# Altium schematic sheet LoRa_SX1262.SchDoc
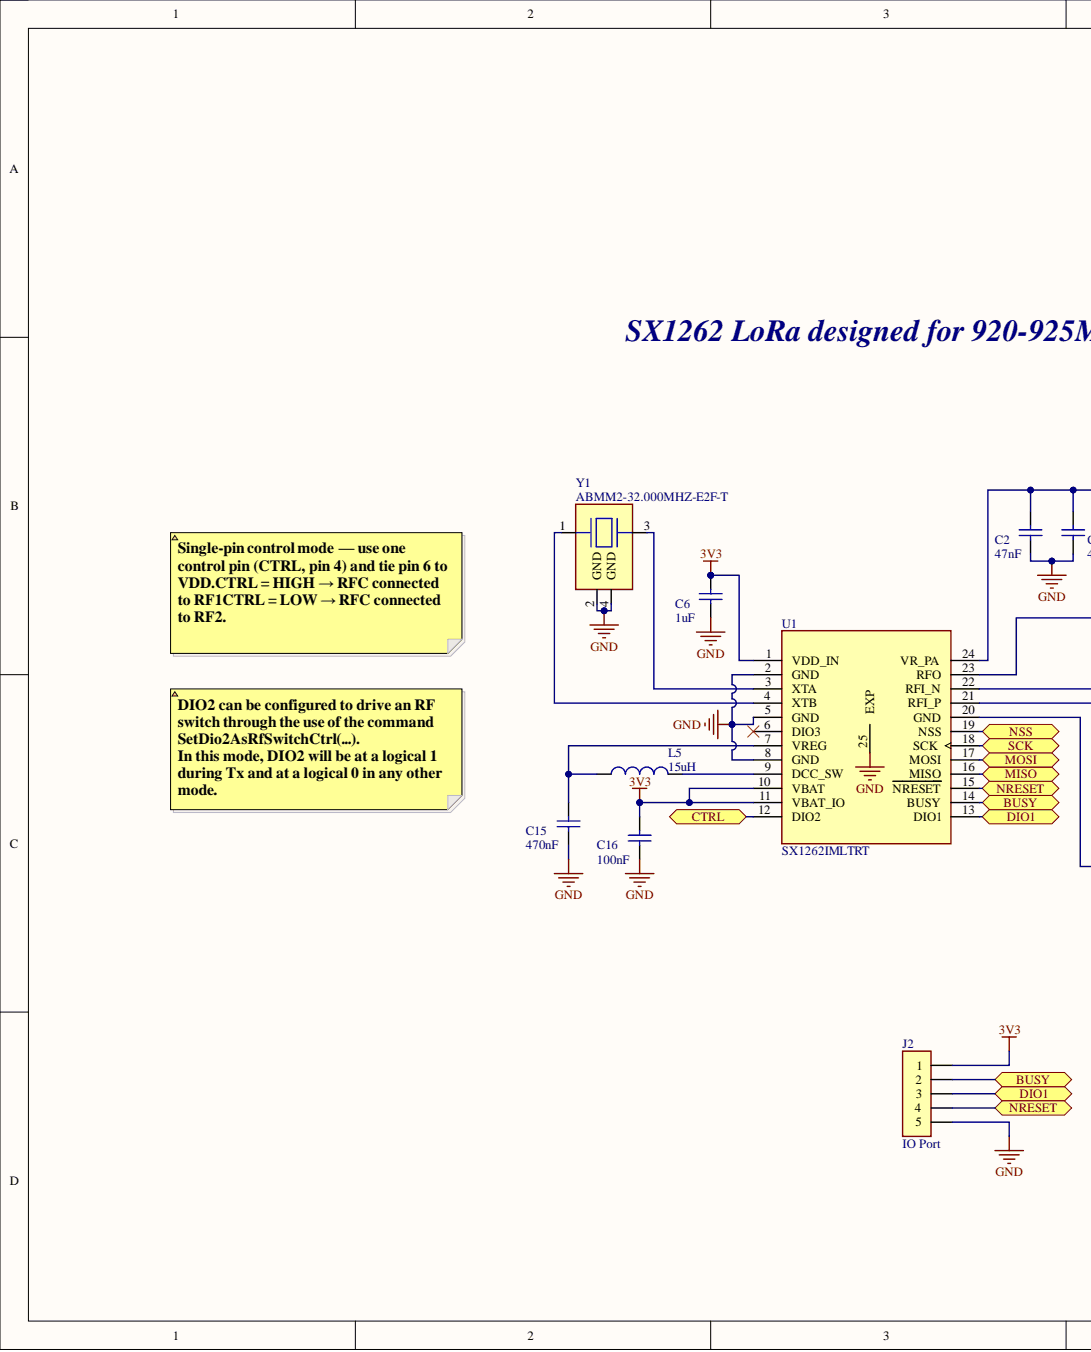
[diagram p0: LoRa_SX1262.SchDoc, left]
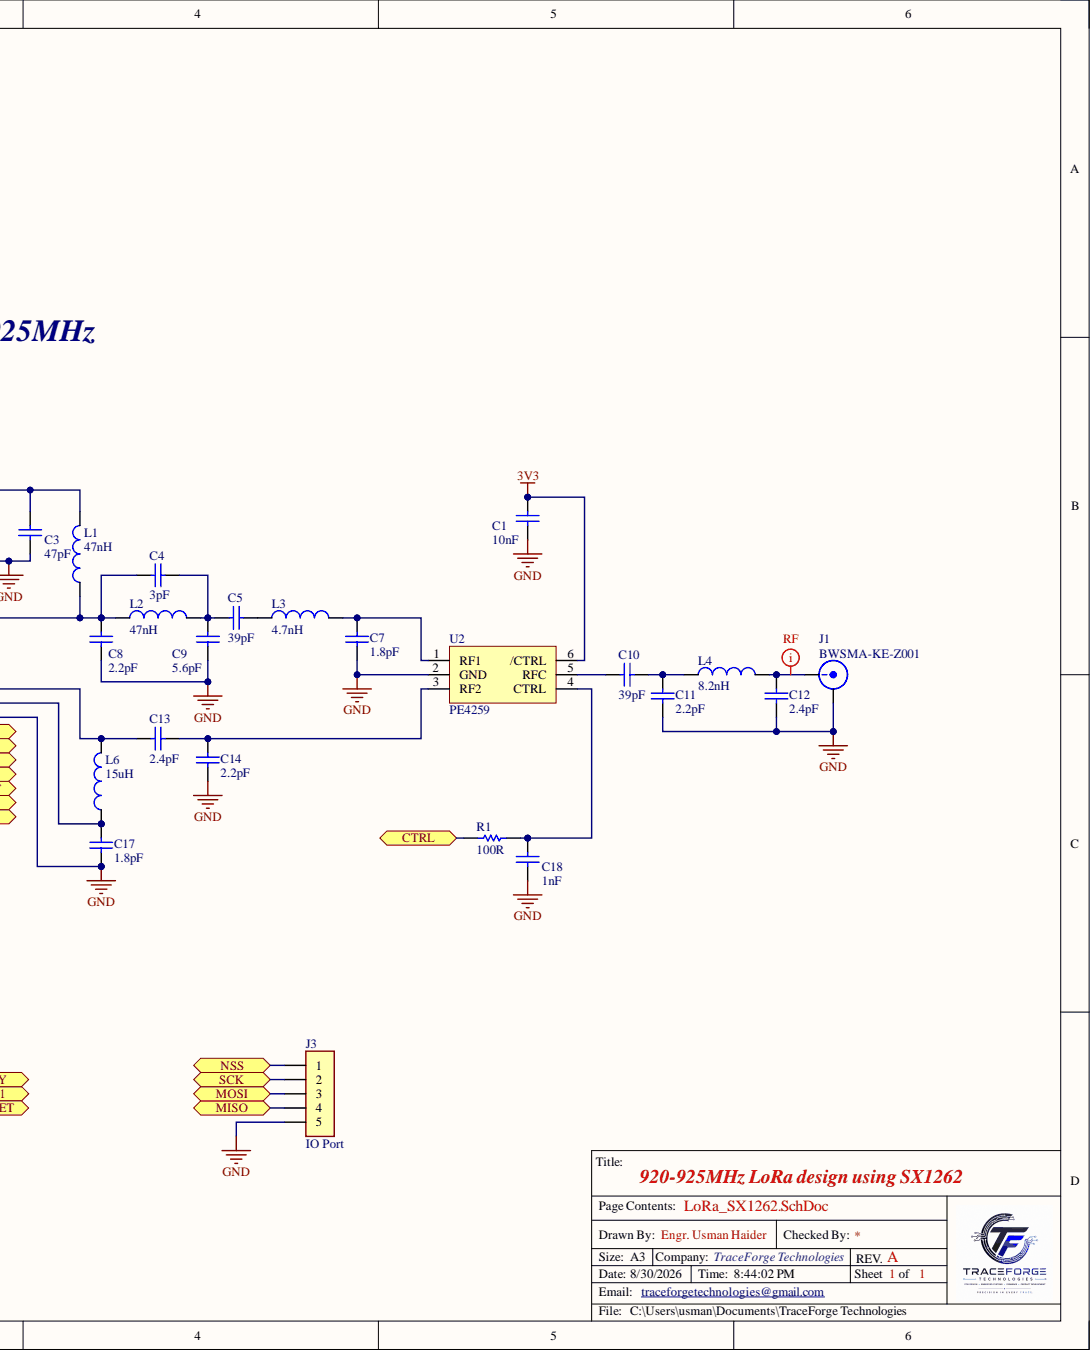
[diagram p1: LoRa_SX1262.SchDoc, right]

## Parts
C1  10nF  [CAPC2012X94N]
C2  47nF  [CAPC2012X94N]
C3  47pF  [CAPC2012X94N]
C4  3pF  [CAPC2012X94N]
C5  39pF  [CAPC2012X94N]
C6  1uF  [CAPC2012X94N]
C7  1.8pF  [CAPC2012X94N]
C8  2.2pF  [CAPC2012X94N]
C9  5.6pF  [CAPC2012X94N]
C10  39pF  [CAPC2012X94N]
C11  2.2pF  [CAPC2012X94N]
C12  2.4pF  [CAPC2012X94N]
C13  2.4pF  [CAPC2012X94N]
C14  2.2pF  [CAPC2012X94N]
C15  470nF  [CAPC2012X94N]
C16  100nF  [CAPC2012X94N]
C17  1.8pF  [CAPC2012X94N]
C18  1nF  [CAPC2012X94N]
J1  BWSMA-KE-Z001  [BAT_WIRELESS_BWSMA-KE-Z001]
J2  IO Port  [TE_5-146280-5]
J3  IO Port  [TE_5-146280-5]
L1  47nH  [INDC2015X152N]
L2  47nH  [INDC2015X152N]
L3  4.7nH  [INDC2012X100N]
L4  8.2nH  [INDC2015X152N]
L5  15uH  [INDC2015X152N]
L6  15uH  [INDC2015X152N]
R1  100R  [RESC2012X60N]
U1  SX1262IMLTRT  [SX1262_Footprints]
U2  PE4259  [SOT65P210X100-6N]
Y1  ABMM2-32.000MHZ-E2F-T  [XTAL_ABMM2-32.000MHZ-E2F-T]

## Ports
BUSY, CTRL, DIO1, MISO, MOSI, NRESET, NSS, SCK

## Nets
3V3: C1.2 C6.2 C16.2 J2.1 U1.1(VDD_IN) U1.10(VBAT) U1.11(VBAT_IO) U2.6(/CTRL)
GND: C1.1 C2.1 C3.1 C6.1 C7.2 C8.2 C9.1 C11.2 C12.2 C14.2 C15.1 C16.1 C17.2 C18.1 J1.GND J2.5 J3.5 U1.2(GND) U1.5(GND) U1.8(GND) U1.20(GND) U1.25(EXP) U2.2(GND) Y1.2(GND) Y1.4(GND)
BUSY: J2.2 U1.14(BUSY) ; port BUSY
CTRL: R1.1 U1.12(DIO2) ; port CTRL
DIO1: J2.3 U1.13(DIO1) ; port DIO1
MISO: J3.4 U1.16(MISO) ; port MISO
MOSI: J3.3 U1.17(MOSI) ; port MOSI
NRESET: J2.4 U1.15(NRESET) ; port NRESET
NSS: J3.1 U1.19(NSS) ; port NSS
SCK: J3.2 U1.18(SCK) ; port SCK
NetC2_2: C2.2 C3.2 L1.2 U1.24(VR_PA)
NetC4_1: C4.1 C5.2 C9.2 L2.2
NetC4_2: C4.2 C8.1 L1.1 L2.1 U1.23(RFO)
NetC5_1: C5.1 L3.1
NetC7_1: C7.1 L3.2 U2.1(RF1)
NetC10_1: C10.1 C11.1 L4.1
NetC10_2: C10.2 U2.5(RFC)
NetC12_1: C12.1 J1.S L4.2
NetC13_1: C13.1 C14.1 U2.3(RF2)
NetC13_2: C13.2 L6.2 U1.22(RFI_N)
NetC15_2: C15.2 L5.1 U1.7(VREG)
NetC17_1: C17.1 L6.1 U1.21(RFI_P)
NetC18_2: C18.2 R1.2 U2.4(CTRL)
NetL5_2: L5.2 U1.9(DCC_SW_GND)
NetU1_3: U1.3(XTA) Y1.3
NetU1_4: U1.4(XTB) Y1.1

Not connected: U1 6(DIO3)

## Library data of the parts
C1 = Cap Semi: Capacitor 10nF 0805; Component Kind: Standard; JLC part #: C1710; LatestRevisionDate: 29-May-2009; LatestRevisionNote: IPC-7351 Footprint Added.; PackageDescription: Chip Capacitor; PackageReference: C1206; Published: 8-Jun-2000; Signal Integrity: Cap Semi; Simulation: CAP; Value: 10nF; Library Name: Outdoor_Standalone.IntLib; Publisher: Altium Limited
C2 = Cap Semi: Capacitor 47nF 0805; Component Kind: Standard; JLC part #: C53134; LatestRevisionDate: 29-May-2009; LatestRevisionNote: IPC-7351 Footprint Added.; PackageDescription: Chip Capacitor; PackageReference: C1206; Published: 8-Jun-2000; Signal Integrity: Cap Semi; Simulation: CAP; Value: 47nF; Library Name: Outdoor_Standalone.IntLib; Publisher: Altium Limited
C3 = Cap Semi: Capacitor 47pF 0805; Component Kind: Standard; JLC part #: C14857; LatestRevisionDate: 29-May-2009; LatestRevisionNote: IPC-7351 Footprint Added.; PackageDescription: Chip Capacitor; PackageReference: C1206; Published: 8-Jun-2000; Signal Integrity: Cap Semi; Simulation: CAP; Value: 47pF; Library Name: Outdoor_Standalone.IntLib; Publisher: Altium Limited
C4 = Cap Semi: Capacitor 3pF 0805; Component Kind: Standard; JLC part #: C237474; LatestRevisionDate: 29-May-2009; LatestRevisionNote: IPC-7351 Footprint Added.; PackageDescription: Chip Capacitor; PackageReference: C1206; Published: 8-Jun-2000; Signal Integrity: Cap Semi; Simulation: CAP; Value: 3pF; Library Name: Outdoor_Standalone.IntLib; Publisher: Altium Limited
C5 C10 = Cap Semi: Capacitor 39pF 0805; Component Kind: Standard; JLC part #: C668331; LatestRevisionDate: 29-May-2009; LatestRevisionNote: IPC-7351 Footprint Added.; PackageDescription: Chip Capacitor; PackageReference: C1206; Published: 8-Jun-2000; Signal Integrity: Cap Semi; Simulation: CAP; Value: 39pF; Library Name: Outdoor_Standalone.IntLib; Publisher: Altium Limited
C6 = Cap Semi: Capacitor 1uF 0805; Component Kind: Standard; JLC part #: C28323; LatestRevisionDate: 29-May-2009; LatestRevisionNote: IPC-7351 Footprint Added.; PackageDescription: Chip Capacitor; PackageReference: C1206; Published: 8-Jun-2000; Signal Integrity: Cap Semi; Simulation: CAP; Value: 1uF; Library Name: Outdoor_Standalone.IntLib; Publisher: Altium Limited
C7 C17 = Cap Semi: Capacitor 1.8pF 0805; Component Kind: Standard; JLC part #: C882550; LatestRevisionDate: 29-May-2009; LatestRevisionNote: IPC-7351 Footprint Added.; PackageDescription: Chip Capacitor; PackageReference: C1206; Published: 8-Jun-2000; Signal Integrity: Cap Semi; Simulation: CAP; Value: 1.8pF; Library Name: Outdoor_Standalone.IntLib; Publisher: Altium Limited
C8 C11 C14 = Cap Semi: Capacitor 2.2pF 0805; Component Kind: Standard; JLC part #: C519933; LatestRevisionDate: 29-May-2009; LatestRevisionNote: IPC-7351 Footprint Added.; PackageDescription: Chip Capacitor; PackageReference: C1206; Published: 8-Jun-2000; Signal Integrity: Cap Semi; Simulation: CAP; Value: 2.2pF; Library Name: Outdoor_Standalone.IntLib; Publisher: Altium Limited
C9 = Cap Semi: Capacitor 5.6pF 0805; Component Kind: Standard; JLC part #: C513555; LatestRevisionDate: 29-May-2009; LatestRevisionNote: IPC-7351 Footprint Added.; PackageDescription: Chip Capacitor; PackageReference: C1206; Published: 8-Jun-2000; Signal Integrity: Cap Semi; Simulation: CAP; Value: 5.6pF; Library Name: Outdoor_Standalone.IntLib; Publisher: Altium Limited
C12 C13 = Cap Semi: Capacitor 2.4pF 0805; Component Kind: Standard; JLC part #: C519934; LatestRevisionDate: 29-May-2009; LatestRevisionNote: IPC-7351 Footprint Added.; PackageDescription: Chip Capacitor; PackageReference: C1206; Published: 8-Jun-2000; Signal Integrity: Cap Semi; Simulation: CAP; Value: 2.4pF; Library Name: Outdoor_Standalone.IntLib; Publisher: Altium Limited
C15 = Cap Semi: Capacitor 470nF 0805; Component Kind: Standard; JLC part #: C13967; LatestRevisionDate: 29-May-2009; LatestRevisionNote: IPC-7351 Footprint Added.; PackageDescription: Chip Capacitor; PackageReference: C1206; Published: 8-Jun-2000; Signal Integrity: Cap Semi; Simulation: CAP; Value: 470nF; Library Name: Outdoor_Standalone.IntLib; Publisher: Altium Limited
C16 = Cap Semi: Capacitor 100nF 0805; Component Kind: Standard; JLC part #: C1711; LatestRevisionDate: 29-May-2009; LatestRevisionNote: IPC-7351 Footprint Added.; PackageDescription: Chip Capacitor; PackageReference: C1206; Published: 8-Jun-2000; Signal Integrity: Cap Semi; Simulation: CAP; Value: 100nF; Library Name: Outdoor_Standalone.IntLib; Publisher: Altium Limited
C18 = Cap Semi: Capacitor 1nF 0805; Component Kind: Standard; JLC part #: C46653; LatestRevisionDate: 29-May-2009; LatestRevisionNote: IPC-7351 Footprint Added.; PackageDescription: Chip Capacitor; PackageReference: C1206; Published: 8-Jun-2000; Signal Integrity: Cap Semi; Simulation: CAP; Value: 1nF; Library Name: Outdoor_Standalone.IntLib; Publisher: Altium Limited
J1 = BWSMA-KE-Z001: THT SMA 50Ω RF Connector; MF: Bat Wireless; Check_prices: https://www.snapeda.com/parts/BWSMA-KE-Z001/Bat+Wireless/view-part/?ref=eda; Component Kind: Standard; JLC part #: C496549; Library Name: BWSMA-KE-Z001.IntLib; MP: BWSMA-KE-Z001; Package: None; SnapEDA_Link: https://www.snapeda.com/parts/BWSMA-KE-Z001/Bat+Wireless/view-part/?ref=snap; Value: BWSMA-KE-Z001
J2 J3 = 5-146280-5: 1X5P 2.54mm Male Header; Availability: Unavailable; Component Kind: Standard; JLC part #: C492404; Library Name: 5-146280-5.IntLib; MP: 5-146280-5; Package: None; Price: None; Value: IO Port
L1 L2 = LQW2BAN47NG00L: Inductor SMD 47nH 1.25A 200mΩ 0805; Availability: Unavailable; Component Kind: Standard; JLC part #: C2047552; Library Name: LQW2BAN47NG00L.IntLib; MP: LQW2BAN47NG00L; Package: None; Price: None; Value: 47nH
L3 = LQM21PZ4R7NGRD: Inductor SMD 4.7nH 400mA 200mΩ 0805; Availability: Unavailable; Component Kind: Standard; JLC part #: C578021; Library Name: LQM21PZ4R7NGRD.IntLib; MP: LQM21PZ4R7NGRD; Package: None; Price: None; Value: 4.7nH
L4 = LQW2BAS8N2J00L: Inductor SMD 8.2nH 600mA 120mΩ 0805; Availability: Unavailable; Component Kind: Standard; JLC part #: C341801; Library Name: LQW2BAS8N2J00L (1).IntLib; MP: LQW2BAS8N2J00L; Package: None; Price: None; Value: 8.2nH
L5 L6 = LQW2BAS15NG00L: Inductor SMD 15uH 250mA 950mΩ 0805; Availability: Unavailable; Component Kind: Standard; JLC part #: C404760; Library Name: LQW2BAS15NG00L.IntLib; MP: LQW2BAS15NG00L; Package: None; Price: None; Value: 15uH
R1 = Res: Resistor 100R 0805; ASiD Part Number: Res-AS; Component Kind: Standard; JLC part #: C105577; Library Name: Mylib+Rev+4.IntLib; Value: 100R
U1 = SX1262IMLTRT: IC RF LoRaWAN with 150MHz~960MHz VFQFN; MF: Semtech Corporation; Availability: Unavailable; Component Kind: Standard; JLC part #: C191341; Library Name: SX1262IMLTRT (1).IntLib; MP: SX1262IMLTRT; Package: VFQFN-24 Semtech; Price: None; SnapEDA_Link: https://www.snapeda.com/parts/SX1262IMLTRT/Semtech%20Corporation/view-part/2781045/?ref=snap; Value: SX1262IMLTRT
U2 = PE4259: SPDT 30dB RF Switch SC-70-6; Component Kind: Standard; JLC part #: C470892; Library Name: PE4259.IntLib; value: PE4259
Y1 = ABMM2-32.000MHZ-E2F-T: SMD Crystal 32.000MHz 18pF; MF: Abracon; Availability: In Stock; Check_prices: https://www.snapeda.com/parts/ABMM2-32.000MHZ-E2F-T/Abracon/view-part/?ref=eda; Component Kind: Standard; JLC part #: C388797; Library Name: ABMM2-32.000MHZ-E2F-T.IntLib; MP: ABMM2-32.000MHZ-E2F-T; Package: SMD-4 Abracon; Price: None; Purchase-URL: https://pricing.snapeda.com/search/part/ABMM2-32.000MHZ-E2F-T/?ref=eda; SnapEDA_Link: https://www.snapeda.com/parts/ABMM2-32.000MHZ-E2F-T/Abracon/view-part/?ref=snap; Value: ABMM2-32.000MHZ-E2F-T
